# Altium schematic sheet Charge _ Discharge.SchDoc
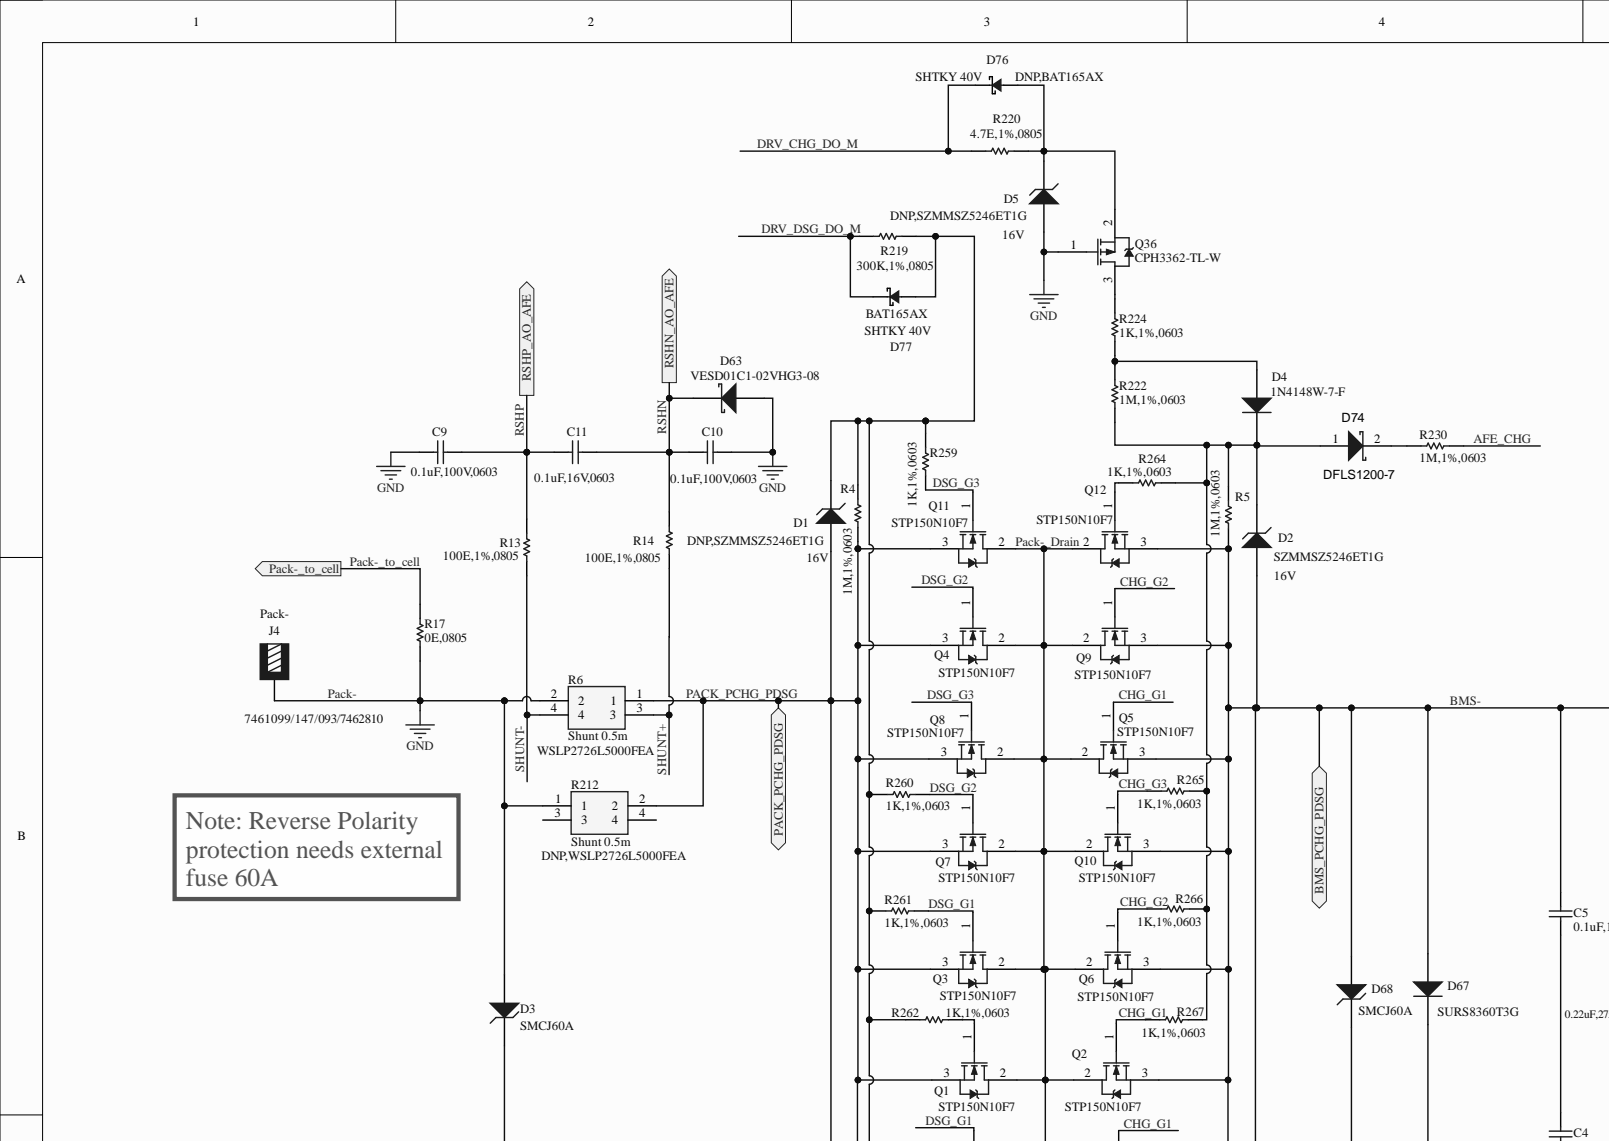
[diagram p0: Charge _ Discharge.SchDoc, top left]
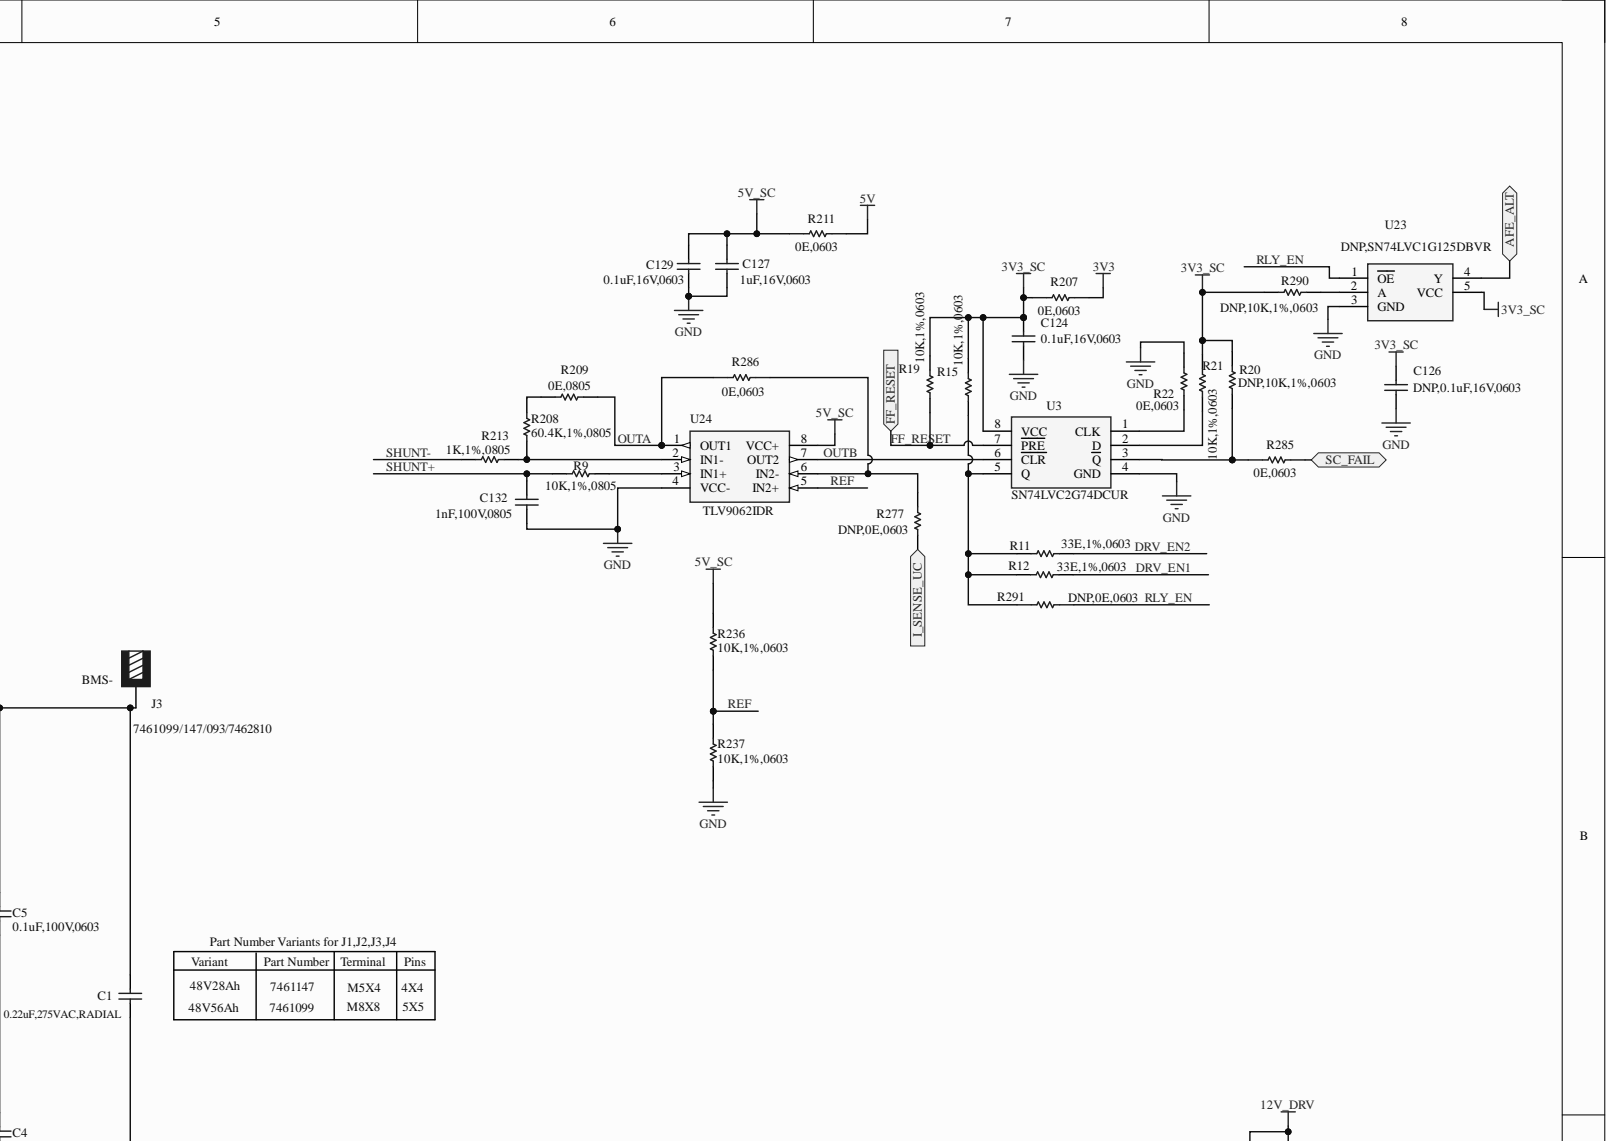
[diagram p1: Charge _ Discharge.SchDoc, top right]
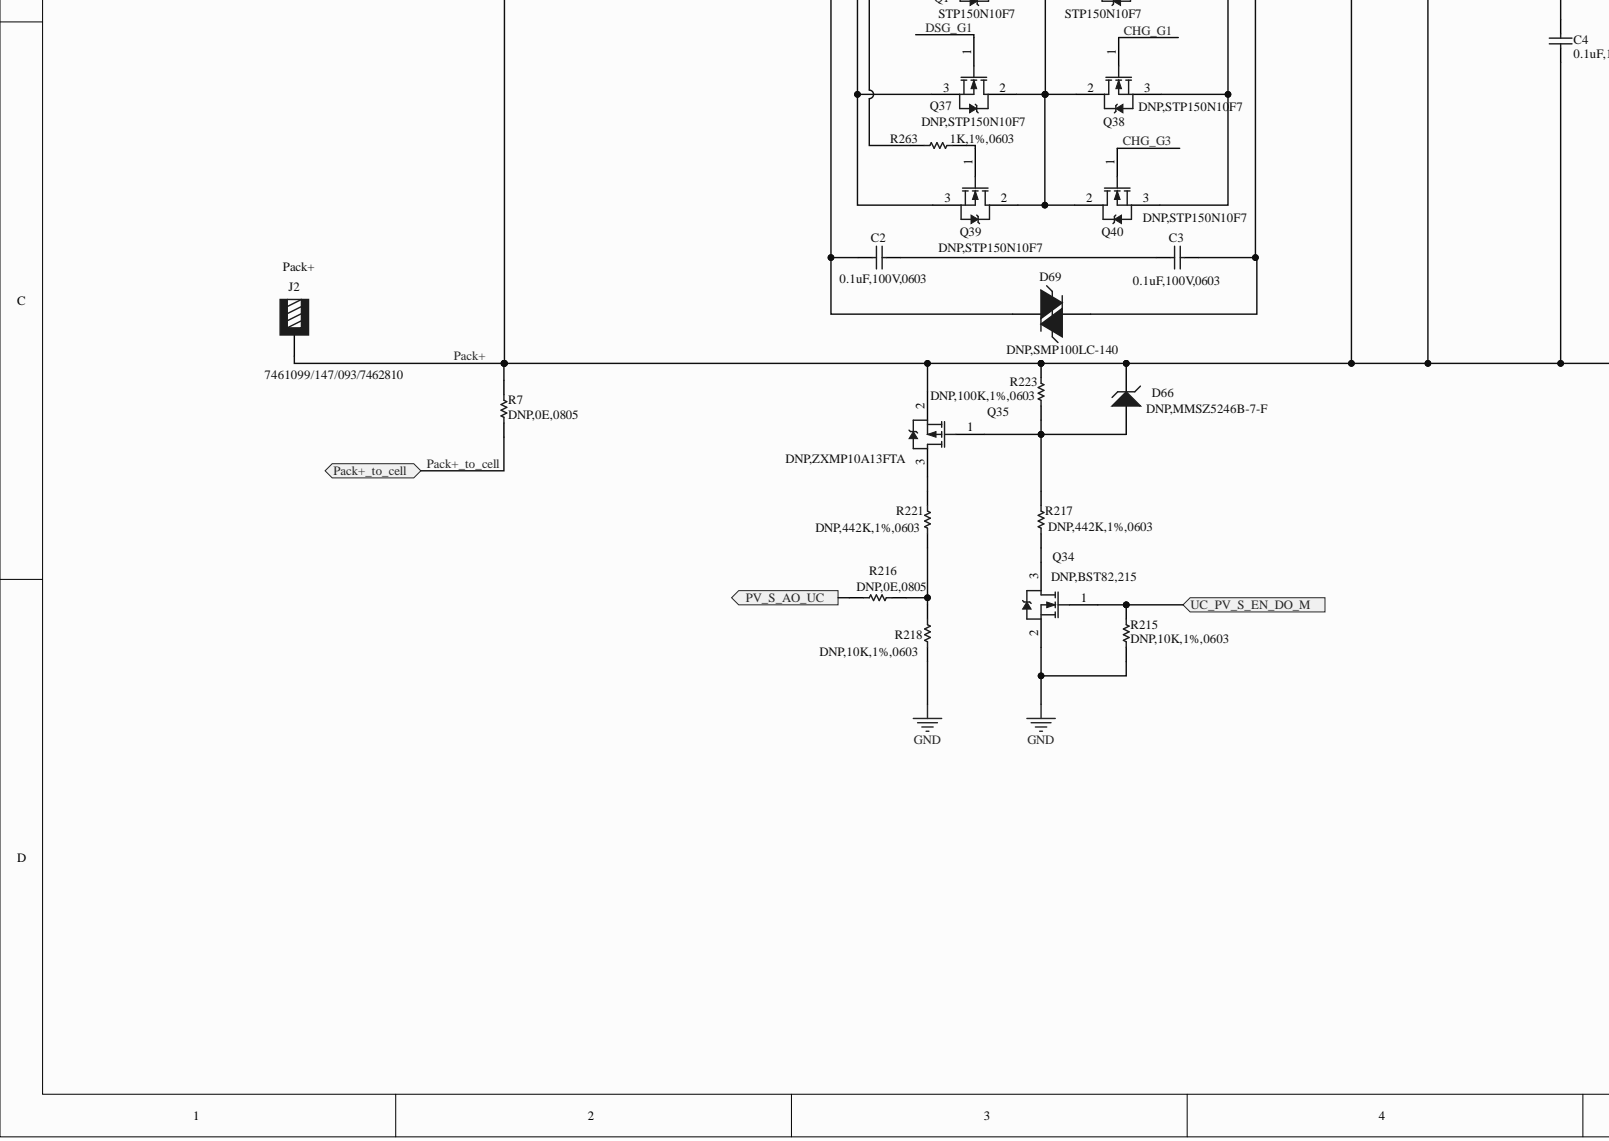
[diagram p2: Charge _ Discharge.SchDoc, bottom left]
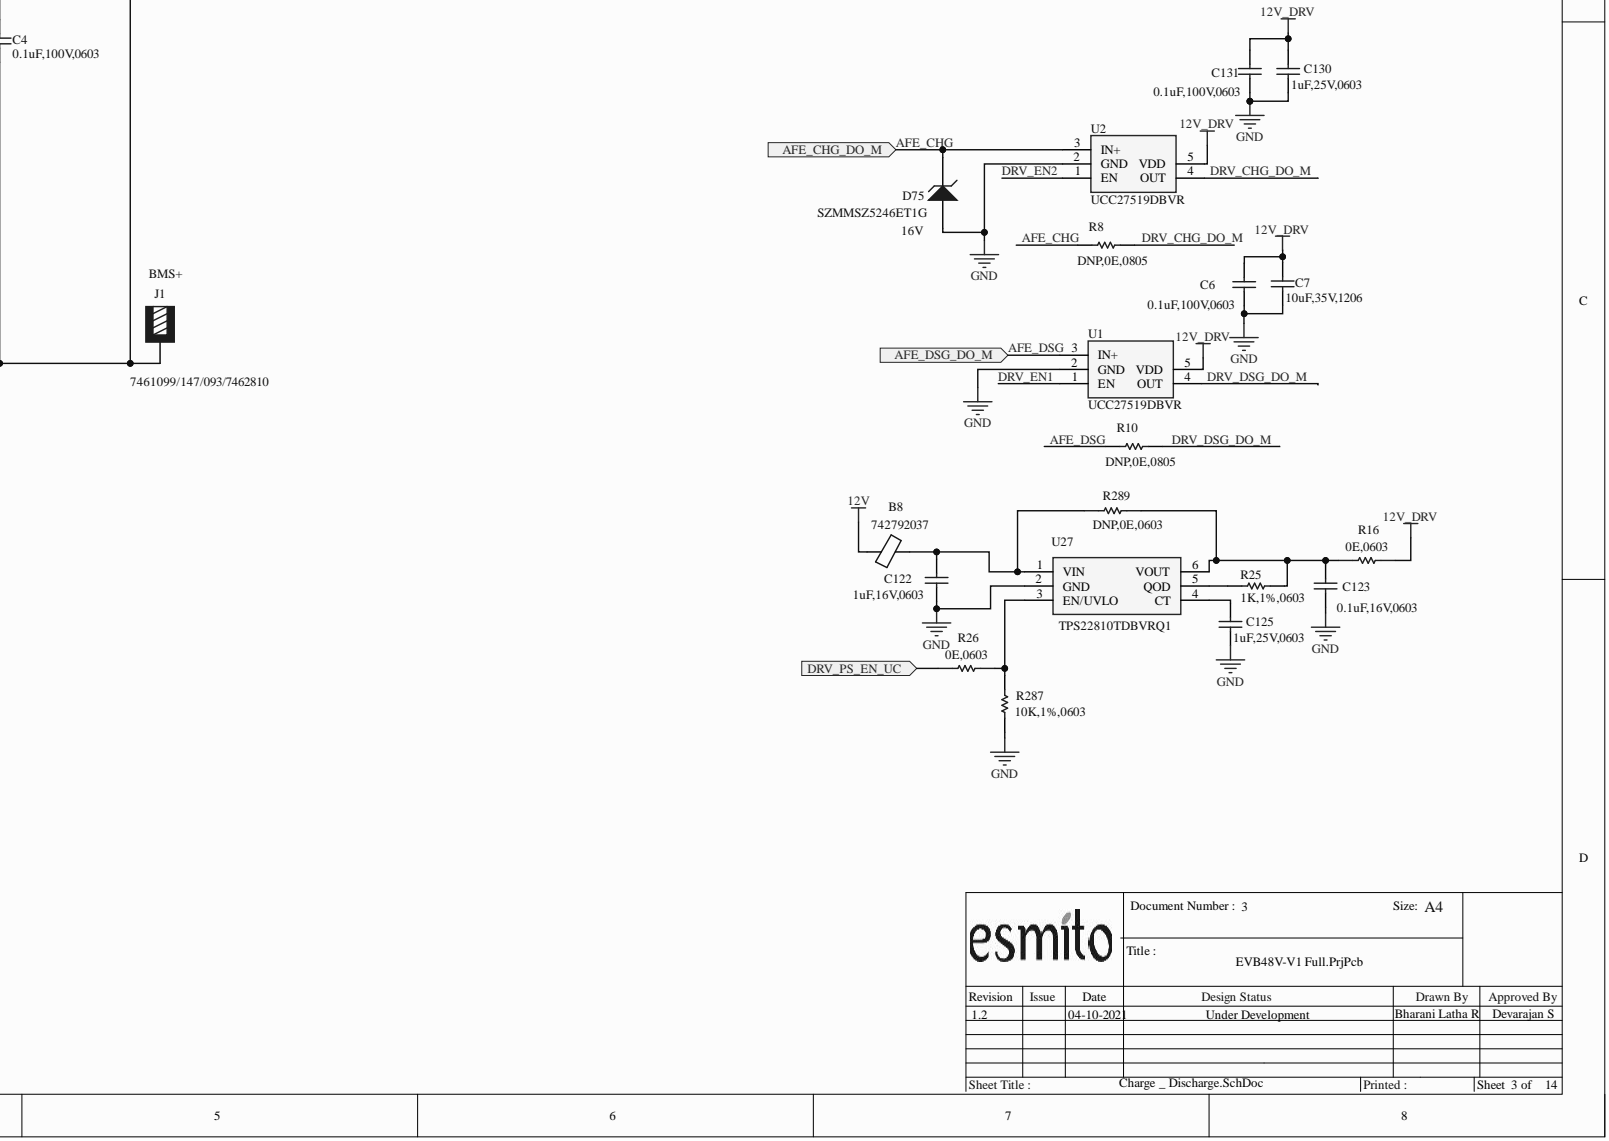
[diagram p3: Charge _ Discharge.SchDoc, bottom right]

## Parts
B8  value 742792037  Part Number: 742792037  [0805_R_M]  (hidden comment: B_LowQ)
C1  value 0.22uF,275VAC,RADIAL  [cap_15ls_0d8_17_5x14_7_5]  (hidden comment: C_Semi)
C2  value 0.1uF,100V,0603  [0603_C_M]  (hidden comment: C_Semi)
C3  value 0.1uF,100V,0603  [0603_C_M]  (hidden comment: C_Semi)
C4  value 0.1uF,100V,0603  [0603_C_M]  (hidden comment: C_Semi)
C5  value 0.1uF,100V,0603  [0603_C_M]  (hidden comment: C_Semi)
C6  value 0.1uF,100V,0603  [0603_C_M]  (hidden comment: C_Semi)
C7  value 10uF,35V,1206  [1206_C_M]  (hidden comment: C_Semi)
C9  value 0.1uF,100V,0603  [0603_C_M]  (hidden comment: C_Semi)
C10  value 0.1uF,100V,0603  [0603_C_M]  (hidden comment: C_Semi)
C11  value 0.1uF,16V,0603  [0603_C_M]  (hidden comment: C_Semi)
C122  value 1uF,16V,0603  [0603_C_M]  (hidden comment: C_Semi)
C123  value 0.1uF,16V,0603  [0603_C_M]  (hidden comment: C_Semi)
C124  value 0.1uF,16V,0603  [0603_C_M]  (hidden comment: C_Semi)
C125  value 1uF,25V,0603  [0603_C_M]  (hidden comment: C_Semi)
C126  value DNP,0.1uF,16V,0603  [0603_C_M]  (hidden comment: C_Semi)
C127  value 1uF,16V,0603  [0603_C_M]  (hidden comment: C_Semi)
C129  value 0.1uF,16V,0603  [0603_C_M]  (hidden comment: C_Semi)
C130  value 1uF,25V,0603  [0603_C_M]  (hidden comment: C_Semi)
C131  value 0.1uF,100V,0603  [0603_C_M]  (hidden comment: C_Semi)
C132  value 1nF,100V,0805  [0805_R_M]  (hidden comment: C_Semi)
D1  16V  value DNP,SZMMSZ5246ET1G  [SOD_123_M]
D2  16V  value SZMMSZ5246ET1G  Alternate Part Number: SZMMSZ5246ET1G  [SOD_123_M]
D3  value SMCJ60A  Part Number: SMCJ60A  [SMC]  (hidden comment: D_Zener)
D4  value 1N4148W-7-F  Part Number: 1N4148W-7-F  [SOD_123_M]  (hidden comment: D_Standard)
D5  16V  value DNP,SZMMSZ5246ET1G  [SOD_123_M]
D63  value VESD01C1-02VHG3-08  Alternate Part Number: VESD01C1-02VHG3-08  [DO-219AD_SOD-523]  (hidden comment: D_Schottky)
D66  value DNP,MMSZ5246B-7-F  [SOD_123_M]  (hidden comment: D_Zener)
D67  value SURS8360T3G  Part Number: SURS8360T3G  [SMC]  (hidden comment: D_Standard)
D68  value SMCJ60A  Part Number: SMCJ60A  [SMC]  (hidden comment: D_Zener)
D69  SMP100LC-140  value DNP,SMP100LC-140  [SMB_SMC]
D74  DFLS1200-7  [POWERDI123]
D75  16V  value SZMMSZ5246ET1G  Alternate Part Number: SZMMSZ5246ET1G  [SOD_123_M]
D76  SHTKY 40V  value DNP,BAT165AX  [SOD_323_M]
D77  SHTKY 40V  value BAT165AX  Part Number: BAT165AX  [SOD_323_M]
J1  BMS+  value 7461099/147/093/7462810  [7461099/147/093/7462810]
J2  Pack+  value 7461099/147/093/7462810  [7461099/147/093/7462810]
J3  BMS-  value 7461099/147/093/7462810  [7461099/147/093/7462810]
J4  Pack-  value 7461099/147/093/7462810  [7461099/147/093/7462810]
Q1  STP150N10F7  [TO-220 - v1]
Q2  STP150N10F7  [TO-220 - v1]
Q3  STP150N10F7  [TO-220 - v1]
Q4  STP150N10F7  [TO-220 - v1]
Q5  STP150N10F7  [TO-220 - v1]
Q6  STP150N10F7  [TO-220 - v1]
Q7  STP150N10F7  [TO-220 - v1]
Q8  STP150N10F7  [TO-220 - v1]
Q9  STP150N10F7  [TO-220 - v1]
Q10  STP150N10F7  [TO-220 - v1]
Q11  STP150N10F7  [TO-220 - v1]
Q12  STP150N10F7  [TO-220 - v1]
Q34  value DNP,BST82,215  [SOT23_3_M]  (hidden comment: MOS-N_E_Power)
Q35  value DNP,ZXMP10A13FTA  [SOT23_3_M]  (hidden comment: MOS-P_E_Power)
Q36  value CPH3362-TL-W  Part Number: CPH3362-TL-W  [SOT23_3_L _2]  (hidden comment: MOS-P_E_Power)
Q37  STP150N10F7  value DNP,STP150N10F7  [TO-220 - v1]
Q38  STP150N10F7  value DNP,STP150N10F7  [TO-220 - v1]
Q39  STP150N10F7  value DNP,STP150N10F7  [TO-220 - v1]
Q40  STP150N10F7  value DNP,STP150N10F7  [TO-220 - v1]
R4  value 1M,1%,0603  [0603_R_M]  (hidden comment: R_Semi)
R5  value 1M,1%,0603  [0603_R_M]  (hidden comment: R_Semi)
R6  Shunt 0.5m  value WSLP2726L5000FEA  Part Number: WSLP2726L5000FEA  [WSLP27265L000FEA]
R7  value DNP,0E,0805  [0805_R_M]  (hidden comment: R_Semi)
R8  value DNP,0E,0805  [0805_R_M]  (hidden comment: R_Semi)
R9  value 10K,1%,0805  [0805_R_M]  (hidden comment: R_Semi)
R10  value DNP,0E,0805  [0805_R_M]  (hidden comment: R_Semi)
R11  value 33E,1%,0603  [0603_R_M]  (hidden comment: R_Semi)
R12  value 33E,1%,0603  [0603_R_M]  (hidden comment: R_Semi)
R13  value 100E,1%,0805  [0805_R_M]  (hidden comment: R_Semi)
R14  value 100E,1%,0805  [0805_R_M]  (hidden comment: R_Semi)
R15  value 10K,1%,0603  [0603_R_M]  (hidden comment: R_Semi)
R16  value 0E,0603  [0603_R_M]  (hidden comment: R_Semi)
R17  value 0E,0805  [0805_R_M]  (hidden comment: R_Semi)
R19  value 10K,1%,0603  [0603_R_M]  (hidden comment: R_Semi)
R20  value DNP,10K,1%,0603  [0603_R_M]  (hidden comment: R_Semi)
R21  value 10K,1%,0603  [0603_R_M]  (hidden comment: R_Semi)
R22  value 0E,0603  [0603_R_M]  (hidden comment: R_Semi)
R25  value 1K,1%,0603  [0603_R_M]  (hidden comment: R_Semi)
R26  value 0E,0603  [0603_R_M]  (hidden comment: R_Semi)
R207  value 0E,0603  [0603_R_M]  (hidden comment: R_Semi)
R208  value 60.4K,1%,0805  [0805_R_M]  (hidden comment: R_Semi)
R209  value 0E,0805  [0805_R_M]  (hidden comment: R_Semi)
R211  value 0E,0603  [0603_R_M]  (hidden comment: R_Semi)
R212  Shunt 0.5m  value DNP,WSLP2726L5000FEA  Part Number: WSLP2726L5000FEA  [WSLP27265L000FEA]
R213  value 1K,1%,0805  [0805_R_M]  (hidden comment: R_Semi)
R215  value DNP,10K,1%,0603  [0603_R_M]  (hidden comment: R_Semi)
R216  value DNP,0E,0805  [0805_R_M]  (hidden comment: R_Semi)
R217  value DNP,442K,1%,0603  [0603_R_M]  (hidden comment: R_Semi)
R218  value DNP,10K,1%,0603  [0603_R_M]  (hidden comment: R_Semi)
R219  value 300K,1%,0805  [0805_R_M]  (hidden comment: R_Semi)
R220  value 4.7E,1%,0805  [0805_R_M]  (hidden comment: R_Semi)
R221  value DNP,442K,1%,0603  [0603_R_M]  (hidden comment: R_Semi)
R222  value 1M,1%,0603  [0603_R_M]  (hidden comment: R_Semi)
R223  value DNP,100K,1%,0603  [0603_R_M]  (hidden comment: R_Semi)
R224  value 1K,1%,0603  [0603_R_M]  (hidden comment: R_Semi)
R230  value 1M,1%,0603  [0603_R_M]  (hidden comment: R_Semi)
R236  value 10K,1%,0603  [0603_R_M]  (hidden comment: R_Semi)
R237  value 10K,1%,0603  [0603_R_M]  (hidden comment: R_Semi)
R259  value 1K,1%,0603  [0603_R_M]  (hidden comment: R_Semi)
R260  value 1K,1%,0603  [0603_R_M]  (hidden comment: R_Semi)
R261  value 1K,1%,0603  [0603_R_M]  (hidden comment: R_Semi)
R262  value 1K,1%,0603  [0603_R_M]  (hidden comment: R_Semi)
R263  value 1K,1%,0603  [0603_R_M]  (hidden comment: R_Semi)
R264  value 1K,1%,0603  [0603_R_M]  (hidden comment: R_Semi)
R265  value 1K,1%,0603  [0603_R_M]  (hidden comment: R_Semi)
R266  value 1K,1%,0603  [0603_R_M]  (hidden comment: R_Semi)
R267  value 1K,1%,0603  [0603_R_M]  (hidden comment: R_Semi)
R277  value DNP,0E,0603  [0603_R_M]  (hidden comment: R_Semi)
R285  value 0E,0603  [0603_R_M]  (hidden comment: R_Semi)
R286  value 0E,0603  [0603_R_M]  (hidden comment: R_Semi)
R287  value 10K,1%,0603  [0603_R_M]  (hidden comment: R_Semi)
R289  value DNP,0E,0603  [0603_R_M]  (hidden comment: R_Semi)
R290  value DNP,10K,1%,0603  [0603_R_M]  (hidden comment: R_Semi)
R291  value DNP,0E,0603  [0603_R_M]  (hidden comment: R_Semi)
U1  value UCC27519DBVR  Part Number: UCC27519DBVR  [SOT95P280X145-5N]  (hidden comment: UCC27519DBVT)
U2  value UCC27519DBVR  Part Number: UCC27519DBVR  [SOT95P280X145-5N]  (hidden comment: UCC27519DBVT)
U3  value SN74LVC2G74DCUR  Part Number: SN74LVC2G74DCUR  [SOP50P310X90-8N]  (hidden comment: SN74LVC1G74DCUR)
U23  SN74LVC1G125DBVR  value DNP,SN74LVC1G125DBVR  [SOT95P280X145-5N]
U24  value TLV9062IDR  Part Number: TLV9062IDR  [SOIC127P600X175-8N]  (hidden comment: TSV912IDT)
U27  TPS22810TDBVRQ1  [SOT95P280X145-6N]

## Ports
AFE_ALT, AFE_CHG_DO_M, AFE_DSG_DO_M, BMS_PCHG_PDSG, DRV_PS_EN_UC, FF_RESET, I_SENSE_UC, Pack+_to_cell, Pack-_to_cell, PACK_PCHG_PDSG, PV_S_AO_UC, RSHN_AO_AFE, RSHP_AO_AFE, SC_FAIL, UC_PV_S_EN_DO_M

## Nets
3V3: R207.2
3V3_SC: C124.2 C126.2 R15.2 R19.2 R20.2 R21.2 R207.1 R290.2 U3.8(VCC) U23.5(VCC)
5V: R211.2
5V_SC: C127.1 C129.2 R211.1 R236.2 U24.8(VCC+)
12V: B8.1
12V_DRV: C6.2 C7.1 C130.1 C131.2 R16.2 U1.5(VDD) U2.5(VDD)
AFE_ALT: U23.4(Y) ; port AFE_ALT
AFE_CHG: D75.2 R8.2 R230.1 U2.3(IN+) ; port AFE_CHG_DO_M
AFE_DSG: R10.2 U1.3(IN+) ; port AFE_DSG_DO_M
BMS-: C1.2 C3.1 C5.2 D2.1 D67.1 D68.1 D69.1 J3.1 Q2.3 Q5.3 Q6.3 Q9.3 Q10.3 Q12.3 Q38.3 Q40.3 R5.2 ; port BMS_PCHG_PDSG
CHG_G1: Q2.1 Q5.1 Q38.1 R267.2
CHG_G2: Q6.1 Q9.1 R266.2
CHG_G3: Q10.1 Q40.1 R265.2
DRV_CHG_DO_M: D76.2 R8.1 R220.2 U2.4(OUT)
DRV_DSG_DO_M: D77.2 R10.1 R219.2 U1.4(OUT)
DRV_EN1: R12.2 U1.1(EN)
DRV_EN2: R11.2 U2.1(EN)
DRV_PS_EN_UC: R26.1 ; port DRV_PS_EN_UC
DSG_G1: Q3.1 Q37.1 R261.1
DSG_G2: Q4.1 Q7.1 R260.1
DSG_G3: Q8.1 Q11.1 R259.1
FF_RESET: R19.1 U3.7(PRE) ; port FF_RESET
I_SENSE_UC: R277.2 ; port I_SENSE_UC
OUTA: R209.1 R286.1 U24.1(OUT1)
OUTB: U3.6(CLR) U24.7(OUT2)
Pack+: C1.1 C4.1 D3.2 D66.2 D67.2 D68.2 J1.1 J2.1 Q35.2 R7.1 R223.2
Pack+_to_cell: R7.2 ; port Pack+_to_cell
Pack-: C6.1 C7.2 C9.2 C10.1 C122.2 C123.1 C124.1 C125.2 C126.1 C127.2 C129.1 C130.2 C131.1 C132.1 D3.1 D5.1 D63.1 D75.1 J4.1 Q34.2 Q36.1 R6.2 R17.2 R22.2 R212.1 R215.1 R218.1 R237.1 R287.1 U1.2(GND) U2.2(GND) U3.4(GND) U23.3(GND) U24.4(VCC-) U27.2(GND)
Pack-_Drain: Q1.2 Q2.2 Q3.2 Q4.2 Q5.2 Q6.2 Q7.2 Q8.2 Q9.2 Q10.2 Q11.2 Q12.2 Q37.2 Q38.2 Q39.2 Q40.2
Pack-_to_cell: R17.1 ; port Pack-_to_cell
PACK_PCHG_PDSG: C2.2 D1.1 D69.2 Q1.3 Q3.3 Q4.3 Q7.3 Q8.3 Q11.3 Q37.3 Q39.3 R4.2 R6.1 R212.2 ; port PACK_PCHG_PDSG
PV_S_AO_UC: R216.2 ; port PV_S_AO_UC
REF: R236.1 R237.2 U24.5(IN2+)
RLY_EN: R291.2 U23.1(OE)
RSHN: C10.2 C11.1 D63.2 R14.2 ; port RSHN_AO_AFE
RSHP: C9.1 C11.2 R13.2 ; port RSHP_AO_AFE
SC_FAIL: R285.2 ; port SC_FAIL
SHUNT+: C132.2 R6.3 R9.1 R14.1
SHUNT-: R6.4 R13.1 R213.1
UC_PV_S_EN_DO_M: Q34.1 R215.2 ; port UC_PV_S_EN_DO_M
NetB8_2: B8.2 C122.1 R289.1 U27.1(VIN)
NetC2_1: C2.1 C3.2
NetC4_2: C4.2 C5.1
NetC123_2: C123.2 R16.1 R25.2 R289.2 U27.6(VOUT)
NetC125_1: C125.1 U27.4(CT)
NetD1_2: D1.2 D77.1 R4.1 R219.1 R259.2 R260.2 R261.2 R262.2 R263.2
NetD2_2: D2.2 D4.2 D74.1 R5.1 R222.2 R264.2 R265.1 R266.1 R267.1
NetD4_1: D4.1 R222.1 R224.1
NetD5_2: D5.2 D76.1 Q36.2 R220.1
NetD66_1: D66.1 Q35.1 R217.2 R223.1
NetD74_2: D74.2 R230.2
NetQ1_1: Q1.1 R262.1
NetQ12_1: Q12.1 R264.1
NetQ34_3: Q34.3 R217.1
NetQ35_3: Q35.3 R221.2
NetQ36_3: Q36.3 R224.2
NetQ39_1: Q39.1 R263.1
NetR9_2: R9.2 U24.3(IN1+)
NetR11_1: R11.1 R12.1 R15.1 R291.1 U3.5(Q)
NetR20_1: R20.1 R285.1 U3.3(Q)
NetR21_1: R21.1 U3.2(D)
NetR22_1: R22.1 U3.1(CLK)
NetR25_1: R25.1 U27.5(QOD)
NetR26_2: R26.2 R287.2 U27.3(EN/UVLO)
NetR208_1: R208.1 R209.2
NetR208_2: R208.2 R213.2 U24.2(IN1-)
NetR216_1: R216.1 R218.2 R221.1
NetR277_1: R277.1 R286.2 U24.6(IN2-)
NetR290_1: R290.1 U23.2(A)

Not connected: R212 3 4

## Library data of the parts
B8 = B_LowQ: Ferrite Bead WE-CBF 0805 SMD Bead 100MHz 330Ohm 2A; Alternate Manufacturer: Murata; Alternate Part Number: BLM21PG331SN1D; Manufacturer: Wurth Elektronik; Component Kind: Standard; Library Name: Grinntech_Global_Library.IntLib
C1 = C_Semi: Safety Capacitors 0.22uF 275VAC 10% MPP L/S=15mm; Manufacturer: Panasonic; Part Number: ECQ-UAAF224K; Component Kind: Standard; Signal Integrity: Cap Semi; Simulation: CAP; Library Name: Grinntech_Global_Library.IntLib
C2-C6 C9 C10 C131 = C_Semi: MLCC - 0603 100nF 10% 100V; Manufacturer: Murata; Part Number: CC0603KRX7R0BB104; Component Kind: Standard; Signal Integrity: Cap Semi; Simulation: CAP; Library Name: Grinntech_Global_Library.IntLib
C7 = C_Semi: MLCC - 1206 35V 10uF X7R 10%; Manufacturer: TDK; Part Number: C3216X7R1V106K160AC; Component Kind: Standard; Signal Integrity: Cap Semi; Simulation: CAP; Library Name: Grinntech_Global_Library.IntLib
C11 C123 C124 C126 C129 = C_Semi: MLCC - 0603 100nF 16V X7R 10%; Alternate Manufacturer: AVX; Alternate Part Number: 0603YC104K4T2A; Manufacturer: Yageo; Part Number: CC603KRX7R7BB104; Component Kind: Standard; Signal Integrity: Cap Semi; Simulation: CAP; Library Name: Grinntech_Global_Library.IntLib
C122 C127 = C_Semi: MLCC - 0603 1uF 16V X5R 10%; Alternate Manufacturer: Murata; Alternate Part Number: GCM188R71C105KA64D; Manufacturer: Yageo; Part Number: CC603KRX5R7BB105; Component Kind: Standard; Signal Integrity: Cap Semi; Simulation: CAP; Library Name: Grinntech_Global_Library.IntLib
C125 C130 = C_Semi: MLCC - 0603 1uF 25V X7R 10%; Alternate Manufacturer: Murata; Alternate Part Number: GCM188R71E105KA64D; Manufacturer: Yageo; Part Number: CC0603KRX7R8BB105; Component Kind: Standard; Signal Integrity: Cap Semi; Simulation: CAP; Library Name: Grinntech_Global_Library.IntLib
C132 = C_Semi: MLCC - 0805 1000pF 100V 5%; Manufacturer: Yageo; Part Number: AC0805JRNPO0BN102; Component Kind: Standard; Signal Integrity: Cap Semi; Simulation: CAP; Library Name: Grinntech_Global_Library.IntLib
D1 D5 = D_Zener: Diode, Zener, 16V, SOD-123-2; Alternate Manufacturer: ON Semiconductor; Alternate Part Number: SZMMSZ5246ET1G; Manufacturer: Diodes Incorporated; Part Number: MMSZ5246B-7-F; Component Kind: Standard; Signal Integrity: D Zener; Simulation: ZENER; Library Name: Grinntech_Global_Library.IntLib
D2 D75 = D_Zener: Diode, Zener, 16V, SOD-123-2; Alternate Manufacturer: ON Semiconductor; Manufacturer: Diodes Incorporated; Part Number: MMSZ5246B-7-F; Component Kind: Standard; Signal Integrity: D Zener; Simulation: ZENER; Library Name: Grinntech_Global_Library.IntLib
D3 D68 = D_Zener: ESD Suppressor / TVS Diode 60V; Manufacturer: Littelfuse; Component Kind: Standard; Signal Integrity: D Zener; Simulation: ZENER; Library Name: Grinntech_Global_Library.IntLib
D4 = D_Standard: DIODE GEN PURP 100V 300MA SOD123; Manufacturer: Diodes Incorporated; Component Kind: Standard; Signal Integrity: Diode; Simulation: DIODE; Library Name: Grinntech_Global_Library.IntLib
D63 = D_Schottky: ESD Suppressors / TVS Diodes 100W 3.3V Unidirect; Alternate Manufacturer: Vishay; Part Number: MSP3V3-M3/89A; Component Kind: Standard; Manufacturer: Vishay; Signal Integrity: D Schottky; Simulation: SKYDIODE; Library Name: CLVESB-R10.SCHLIB
D66 = D_Zener: Diode, Zener, 16V, 7.8mA, SOD123-2; Manufacturer: Diodes Incorporated; Part Number: MMSZ5246B-7-F; Component Kind: Standard; Signal Integrity: D Zener; Simulation: ZENER; Library Name: Grinntech_Global_Library.IntLib
D67 = D_Standard: DIODE GEN PURP 600V 3A SMC; Manufacturer: ON Semiconductor; Component Kind: Standard; Signal Integrity: Diode; Simulation: DIODE; Library Name: Grinntech_Global_Library.IntLib
D69 = D_TRISIL: Surge Suppressors 140V 50uA Bidirect; Manufacturer: Vishay; Component Kind: Standard; Part Number: SMP100LC-140; Library Name: CLVESB-R10.SCHLIB
D74 = DFLS1200-7: Schottky Diode 1.0A 200V POWERDI-123; Manufacturer: Diodes Incorporated; Component Kind: Standard; Part Number: DFLS1200-7; Signal Integrity: Diode; Value: DFLS1200-7; Library Name: CLVESB-R10.SCHLIB
D76 = BAT42WS-7-F_1: Schottky Diode 40V 0.75A; Manufacturer: Nexperia; Part Number: BAT165AX; Component Kind: Standard; Library Name: PSFB_uC_RS485.SCHLIB
D77 = BAT42WS-7-F_1: Schottky Diode 40V 0.75A; Manufacturer: Nexperia; Component Kind: Standard; Library Name: PSFB_uC_RS485.SCHLIB
J1-J4 = 7461147: WP-BUFU Press-Fit with Internal thread, full plain, 5x5/7x7/9x9/13x13mm, 4/9/16/25 pins, M3x4/M3x3.5/M5x4/M8x8mm, 70A/130A/180A/250A; Manufacturer: Wurth Elektronik; Component Kind: Standard; Library Name: 7461147.IntLib; Part Number: 7461147
Q1-Q12 Q37-Q40 = STP80N10F7: MOSFET, N-CH, 100V, 110A, TO-220; Manufacturer: STMicroelectronics; Component Kind: Standard; Part Number: STP150N10F7; Simulation: IRF1010; Library Name: CLVESB-R10.SCHLIB
  Q1-Q12: Value: STP150N10F7
Q34 = MOS-N_E_Power: MOSFET, N-CH, 100V, 190mA, SOT23; Manufacturer: Nexperia; Part Number: BST82,215; Component Kind: Standard; Simulation: IRF1010; Library Name: CLVESB-R10.SCHLIB
Q35 = MOS-P_E_Power: MOSFET, P-CH, 100V, 0.7A, CPH3362-TL-W; Manufacturer: Diodes Incorporated; Part Number: ZXMP10A13FTA; Component Kind: Standard; Simulation: IRF9510; Library Name: CLVESB-R10.SCHLIB
Q36 = MOS-P_E_Power: MOSFET, P-CH, 100V, 0.7A, CPH3362-TL-W; Manufacturer: ON Semiconductor; Component Kind: Standard; Simulation: IRF9510; Library Name: CLVESB-R10.SCHLIB
R4 R5 R222 R230 = R_Semi: R, THK FLM, 1M, 1%, 100mW, 0603; Manufacturer: Yageo; Part Number: RC0603FR-071ML; Component Kind: Standard; Signal Integrity: Res Semi; Simulation: RES; Library Name: Grinntech_Global_Library.IntLib
R6 = WSLP27265L000FEA: Current Sense Resistor - SMD 0.0005E 1%; Manufacturer: Vishay; Component Kind: Standard; Library Name: AFE_UC_Active_Conn.SchLib
R7 R8 R10 R17 R216 = R_Semi: Thick Film Resistor - 0805 0E 1% 1/8W; Manufacturer: Yageo; Part Number: RC0805FR-070RL; Component Kind: Standard; Library Name: Grinntech_Global_Library.IntLib
R9 = R_Semi: Resistor - 0805 1/8W 10K 1%; Manufacturer: Yageo; Part Number: RT0805FRE0710KL; Component Kind: Standard; Library Name: Grinntech_Global_Library.IntLib
R11 R12 = R_Semi: R, THK FLM, 33E, 1%, 100mW, 0603; Alternate Manufacturer: Yageo; Alternate Part Number: AC0603FR-0733RL; Part Number: RC0603FR-0733RL; Component Kind: Standard; Manufacturer: Yageo; Signal Integrity: Res Semi; Simulation: RES; Library Name: Grinntech_Global_Library.IntLib
R13 R14 = R_Semi: R, THK FLM, 100E, 1%, 400mW, 0805; Manufacturer: Vishay; Part Number: RCS0805100RFKEA; Component Kind: Standard; Signal Integrity: Res Semi; Simulation: RES; Library Name: Grinntech_Global_Library.IntLib
R15 R19-R21 R215 R218 R236 R237 R287 R290 = R_Semi: R, THK FLM, 10K, 1%, 100mW, 0603; Alternate Manufacturer: Vishay; Alternate Part Number: CRCW060310K0FKEA; Manufacturer: Yageo; Part Number: RC0603FR-0710KL; Component Kind: Standard; Signal Integrity: Res Semi; Simulation: RES; Library Name: Grinntech_Global_Library.IntLib
R16 R26 R207 R211 R285 R286 R289 R291 = R_Semi_R245: R, THK FLM, 0E,100mW,  0603; Alternate Manufacturer: Vishay; Alternate Part Number: CRCW06030000Z0EAC; Manufacturer: Yageo; Part Number: RC0603FR-070RL; Component Kind: Standard; Library Name: CLVESB-R10.SCHLIB
R22 R277 = R_Semi: R, THK FLM, 0E,100mW,  0603; Alternate Manufacturer: Vishay; Alternate Part Number: CRCW06030000Z0EAC; Manufacturer: Yageo; Part Number: RC0603FR-070RL; Component Kind: Standard; Signal Integrity: Res Semi; Simulation: RES; Library Name: Grinntech_Global_Library.IntLib
R25 R224 R259-R265 = R_Semi: R, THK FLM, 1K, 1%, 100mW, 0603; Alternate Manufacturer: Vishay; Alternate Part Number: CRCW06031K00FKEA; Manufacturer: Yageo; Part Number: RC0603FR-071KL; Component Kind: Standard; Signal Integrity: Res Semi; Simulation: RES; Library Name: Grinntech_Global_Library.IntLib
R208 = R_Semi: Resistor - 0805 1/8W 60.4K 1%; Manufacturer: Vishay; Part Number: CRCW080560K4FKEA; Component Kind: Standard; Library Name: Grinntech_Global_Library.IntLib
R209 = R_Semi: Resistor - 0805 1/8W 0E; Manufacturer: Yageo; Part Number: RC0805FR-070RL; Component Kind: Standard; Library Name: Grinntech_Global_Library.IntLib
R212 = WSLP27265L000FEA: Current Sense Resistor - SMD 0.005E 1%; Manufacturer: Vishay; Component Kind: Standard; Library Name: AFE_UC_Active_Conn.SchLib
R213 = R_Semi: Resistor - 0805 1/8W 1K 1%; Manufacturer: Yageo; Part Number: RT0805FRE071KL; Component Kind: Standard; Library Name: Grinntech_Global_Library.IntLib
R217 R221 = R_Semi: RES, THK FLM, 442K, 1%, 0.1W, 0603; Manufacturer: Yageo; Part Number: RC0603FR-07442KL; Component Kind: Standard; Signal Integrity: Res Semi; Simulation: RES; Library Name: Grinntech_Global_Library.IntLib
R219 = R_Semi: R, THK FLM, 300K, 1%, 125mW, 0805; Manufacturer: Yageo; Part Number: RC0805FR-07300KL; Component Kind: Standard; Signal Integrity: Res Semi; Simulation: RES; Library Name: Grinntech_Global_Library.IntLib
R220 = R_Semi: R, THK FLM, 4.7E, 1%, 125mW, 0805; Manufacturer: Yageo; Part Number: RC0805FR-074R7L; Component Kind: Standard; Signal Integrity: Res Semi; Simulation: RES; Library Name: Grinntech_Global_Library.IntLib
R223 = R_Semi: R, THK FLM, 100K, 1%, 100mW, 0603; Alternate Manufacturer: Vishay; Alternate Part Number: CRCW0603100KFKEA; Manufacturer: Yageo; Part Number: RC0603FR-07100KL; Component Kind: Standard; Signal Integrity: Res Semi; Simulation: RES; Library Name: Grinntech_Global_Library.IntLib
R266 R267 = R_Semi: R, THK FLM, 1K, 1%, 100mW, 0603; Alternate Manufacturer: Vishay; Alternate Part Number: CRCW06031K00FKEA; Manufacturer: Yageo; Part Number: RC0603FR-071KL; Value: 1K,1%,0603; Component Kind: Standard; Signal Integrity: Res Semi; Simulation: RES; Library Name: Grinntech_Global_Library.IntLib
U1 U2 = UCC27519DBVT: Gate Driver 4A/4A Sgl Ch Hi-Spd Low-side; Manufacturer: Texas Instruments; Component Kind: Standard; Library Name: AFE_UC_Active_Conn.SchLib
U3 = SN74LVC1G74DCUR: Flip-Flop Positive Edge Trig VSSOP-8; Manufacturer: Texas Instruments; Component Kind: Standard
U23 = SN74LVC1G125DBVR: SINGLE BUS BUFFER GATE; Manufacturer: Texas Instruments; Component Kind: Standard; Part Number: SN74LVC1G125DBVR
U24 = TSV912IDT: CMOS Amplifier 2 Circuit Rail-to-Rail 8-SOIC; Alternate Manufacturer: STMicroelectronics; Manufacturer: Texas Instruments; Alternate Part Number: TSV912IDT; Component Kind: Standard
U27 = TPS22810TDBVRQ1: Load Switch single Channel 6-SOT-23; Manufacturer: Texas Instruments; Component Kind: Standard; Part Number: TPS22810TDBVRQ1; Value: TPS22810TDBVRQ1
